# Altium schematic sheet Serial Monitor LCD Board Schematic.SchDoc("Serial Monitor LCD Board Schematic")
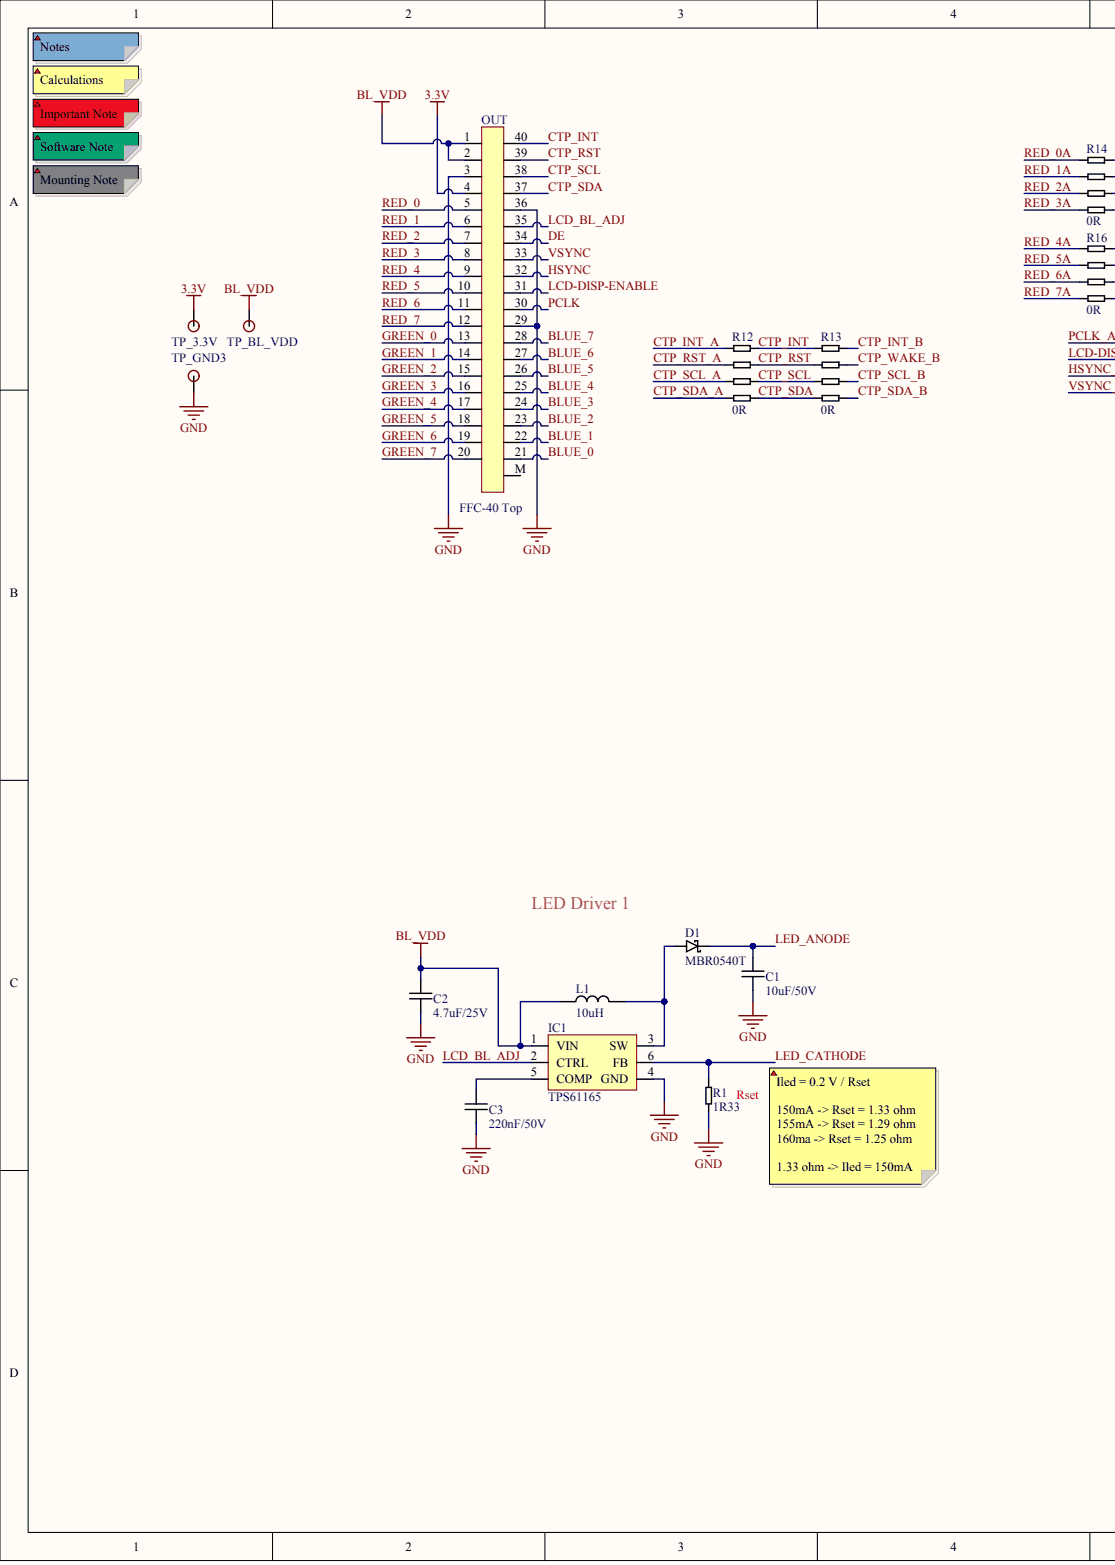
[diagram p0: Serial Monitor LCD Board Schematic.SchDoc, left]
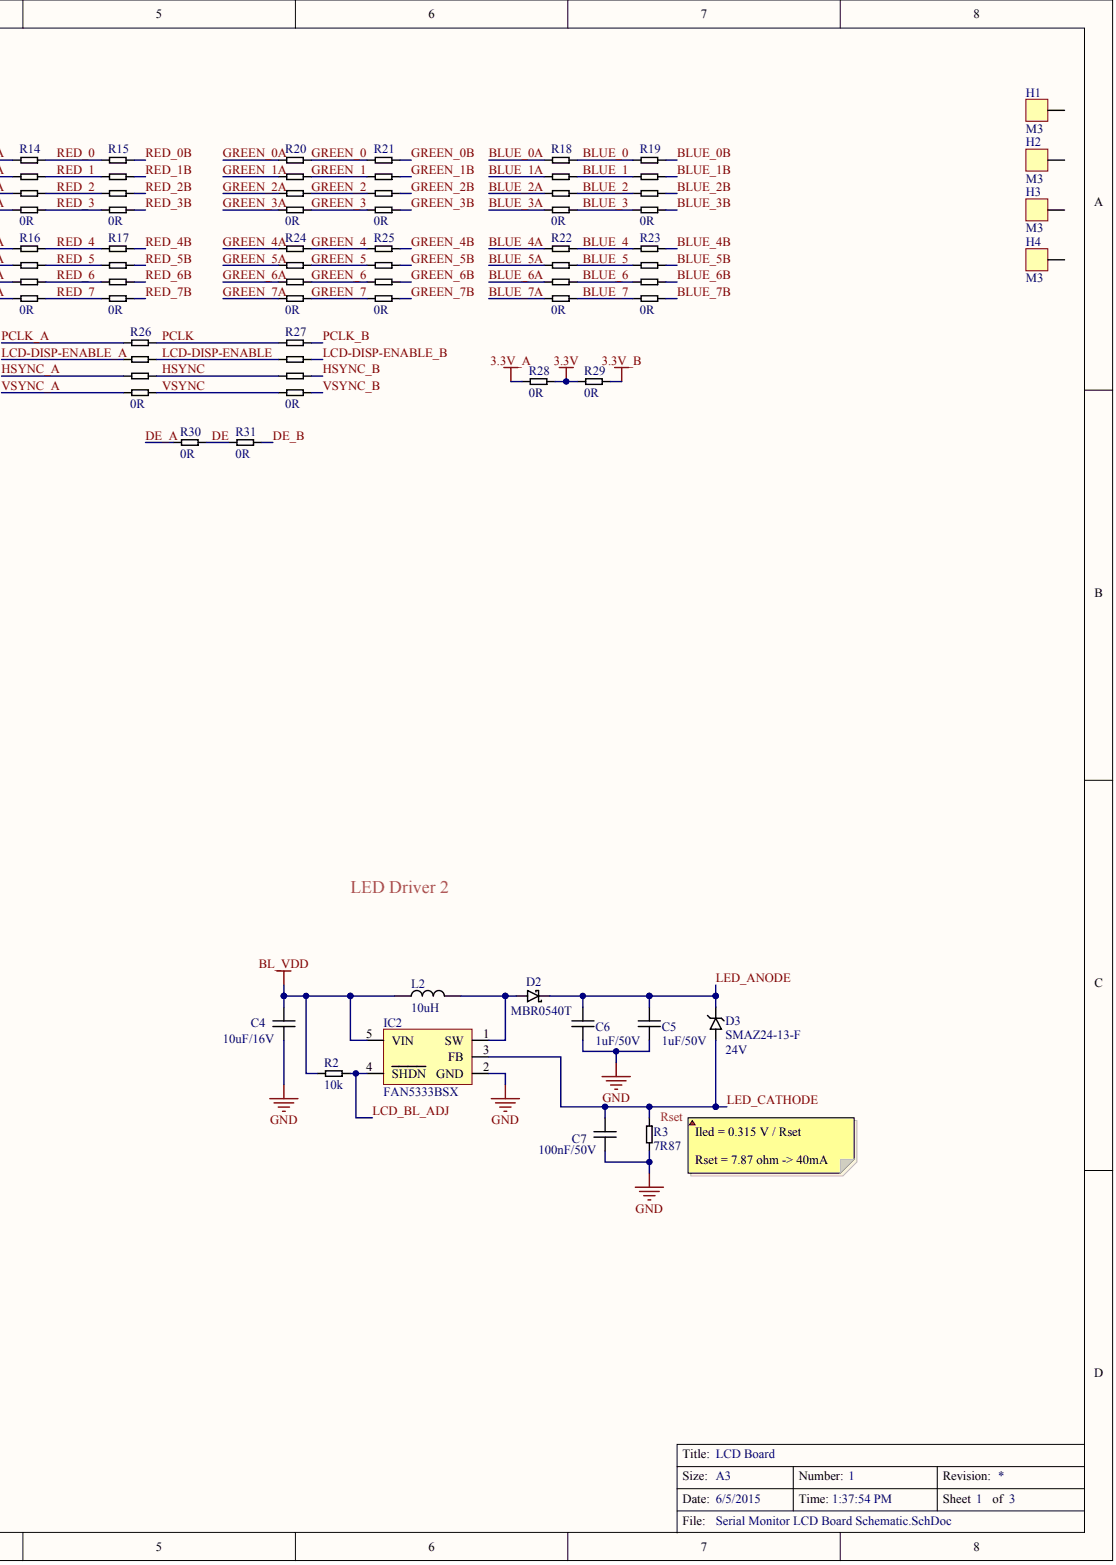
[diagram p1: Serial Monitor LCD Board Schematic.SchDoc, right]

## Parts
C1  value 10uF/50V  [1206]  (hidden comment: Cap_1206_10uF/50V)
C2  value 4.7uF/25V  [0805]  (hidden comment: Cap_0805_4.7uF/25V)
C3  value 220nF/50V  [0603]  (hidden comment: Cap_0603_220nF/50V)
C4  value 10uF/16V  [0805]  (hidden comment: Cap_0805_10uF/16V)
C5  value 1uF/50V  [0603]  (hidden comment: Cap_0603_1uF/50V)
C6  value 1uF/50V  [0603]  (hidden comment: Cap_0603_1uF/50V)
C7  value 100nF/50V  [0603]  (hidden comment: Cap_0603_100nF/50V)
D1  MBR0540  value MBR0540T  Part No.: MBR0540T
D2  MBR0540  value MBR0540T  Part No.: MBR0540T
D3  SMAZ24-13-F  Zener Voltage: 24V
H1  M3_SCREWHOLE  (value not drawn)
H2  value M3  (hidden comment: M3_SCREWHOLE)
H3  value M3  (hidden comment: M3_SCREWHOLE)
H4  value M3  (hidden comment: M3_SCREWHOLE)
IC1  TPS61165  [SOT-23-6]
IC2  FAN5333BSX  [SOT-23-5]
L1  value 10uH  [SMD SRN4028 4.8x4.8mm]  (hidden comment: Inductor_SRR4028_10uH)
L2  value 10uH  [SMD SRN4028 4.8x4.8mm]  (hidden comment: Inductor_SRR4028_10uH)
OUT  value FFC-40 Top  (hidden comment: FFC 40 pin 0.5mm Top)
R1  value 1R33  [0603]  (hidden comment: Res_0603_1R33)
R2  value 10k  [0603]  (hidden comment: Res_0603_10k)
R3  value 7R87  [0603]  (hidden comment: Res_0603_7R87)
R12  value 0R  [0804]  (hidden comment: Res_Array_0804_4x0R)
R13  value 0R  [0804]  (hidden comment: Res_Array_0804_4x0R)
R14  value 0R  [0804]  (hidden comment: Res_Array_0804_4x0R)
R15  value 0R  [0804]  (hidden comment: Res_Array_0804_4x0R)
R16  value 0R  [0804]  (hidden comment: Res_Array_0804_4x0R)
R17  value 0R  [0804]  (hidden comment: Res_Array_0804_4x0R)
R18  value 0R  [0804]  (hidden comment: Res_Array_0804_4x0R)
R19  value 0R  [0804]  (hidden comment: Res_Array_0804_4x0R)
R20  value 0R  [0804]  (hidden comment: Res_Array_0804_4x0R)
R21  value 0R  [0804]  (hidden comment: Res_Array_0804_4x0R)
R22  value 0R  [0804]  (hidden comment: Res_Array_0804_4x0R)
R23  value 0R  [0804]  (hidden comment: Res_Array_0804_4x0R)
R24  value 0R  [0804]  (hidden comment: Res_Array_0804_4x0R)
R25  value 0R  [0804]  (hidden comment: Res_Array_0804_4x0R)
R26  value 0R  [0804]  (hidden comment: Res_Array_0804_4x0R)
R27  value 0R  [0804]  (hidden comment: Res_Array_0804_4x0R)
R28  value 0R  [0603]  (hidden comment: Res_0603_0R)
R29  value 0R  [0603]  (hidden comment: Res_0603_0R)
R30  value 0R  [0603]  (hidden comment: Res_0603_0R)
R31  value 0R  [0603]  (hidden comment: Res_0603_0R)
TP_3.3V  Testpad  (value not drawn)
TP_BL_VDD  Testpad  (value not drawn)
TP_GND3  Testpad  (value not drawn)

## Nets
3.3V: OUT.4 R28.2 R29.1 TP_3.3V.1
3.3V_A: R28.1
3.3V_B: R29.2
BL_VDD: C2.2 C4.2 IC1.1(VIN) IC2.5(VIN) L1.1 L2.1 OUT.1 OUT.2 R2.1 TP_BL_VDD.1
GND: C1.1 C2.1 C3.1 C4.1 C5.1 C6.1 C7.1 IC1.4(GND) IC2.2(GND) OUT.3 OUT.29 OUT.36 R1.1 R3.1 TP_GND3.1
BLUE_0: OUT.21 R18.2 R19.1
BLUE_0A: R18.1
BLUE_0B: R19.2
BLUE_1: OUT.22 R18.4 R19.3
BLUE_1A: R18.3
BLUE_1B: R19.4
BLUE_2: OUT.23 R18.6 R19.5
BLUE_2A: R18.5
BLUE_2B: R19.6
BLUE_3: OUT.24 R18.8 R19.7
BLUE_3A: R18.7
BLUE_3B: R19.8
BLUE_4: OUT.25 R22.2 R23.1
BLUE_4A: R22.1
BLUE_4B: R23.2
BLUE_5: OUT.26 R22.4 R23.3
BLUE_5A: R22.3
BLUE_5B: R23.4
BLUE_6: OUT.27 R22.6 R23.5
BLUE_6A: R22.5
BLUE_6B: R23.6
BLUE_7: OUT.28 R22.8 R23.7
BLUE_7A: R22.7
BLUE_7B: R23.8
CTP_INT: OUT.40 R12.8 R13.7
CTP_INT_A: R12.7
CTP_INT_B: R13.8
CTP_RST: OUT.39 R12.6 R13.5
CTP_RST_A: R12.5
CTP_SCL: OUT.38 R12.4 R13.3
CTP_SCL_A: R12.3
CTP_SCL_B: R13.4
CTP_SDA: OUT.37 R12.2 R13.1
CTP_SDA_A: R12.1
CTP_SDA_B: R13.2
CTP_WAKE_B: R13.6
DE: OUT.34 R30.2 R31.1
DE_A: R30.1
DE_B: R31.2
GREEN_0: OUT.13 R20.2 R21.1
GREEN_0A: R20.1
GREEN_0B: R21.2
GREEN_1: OUT.14 R20.4 R21.3
GREEN_1A: R20.3
GREEN_1B: R21.4
GREEN_2: OUT.15 R20.6 R21.5
GREEN_2A: R20.5
GREEN_2B: R21.6
GREEN_3: OUT.16 R20.8 R21.7
GREEN_3A: R20.7
GREEN_3B: R21.8
GREEN_4: OUT.17 R24.2 R25.1
GREEN_4A: R24.1
GREEN_4B: R25.2
GREEN_5: OUT.18 R24.4 R25.3
GREEN_5A: R24.3
GREEN_5B: R25.4
GREEN_6: OUT.19 R24.6 R25.5
GREEN_6A: R24.5
GREEN_6B: R25.6
GREEN_7: OUT.20 R24.8 R25.7
GREEN_7A: R24.7
GREEN_7B: R25.8
HSYNC: OUT.32 R26.6 R27.5
HSYNC_A: R26.5
HSYNC_B: R27.6
LCD-DISP-ENABLE: OUT.31 R26.4 R27.3
LCD-DISP-ENABLE_A: R26.3
LCD-DISP-ENABLE_B: R27.4
LCD_BL_ADJ: IC1.2(CTRL) IC2.4(SHDN) OUT.35 R2.2
LED_ANODE: C1.2 C5.2 C6.2 D1.1 D2.1 D3.1
LED_CATHODE: C7.2 D3.2 IC1.6(FB) IC2.3(FB) R1.2 R3.2
PCLK: OUT.30 R26.2 R27.1
PCLK_A: R26.1
PCLK_B: R27.2
RED_0: OUT.5 R14.2 R15.1
RED_0A: R14.1
RED_0B: R15.2
RED_1: OUT.6 R14.4 R15.3
RED_1A: R14.3
RED_1B: R15.4
RED_2: OUT.7 R14.6 R15.5
RED_2A: R14.5
RED_2B: R15.6
RED_3: OUT.8 R14.8 R15.7
RED_3A: R14.7
RED_3B: R15.8
RED_4: OUT.9 R16.2 R17.1
RED_4A: R16.1
RED_4B: R17.2
RED_5: OUT.10 R16.4 R17.3
RED_5A: R16.3
RED_5B: R17.4
RED_6: OUT.11 R16.6 R17.5
RED_6A: R16.5
RED_6B: R17.6
RED_7: OUT.12 R16.8 R17.7
RED_7A: R16.7
RED_7B: R17.8
VSYNC: OUT.33 R26.8 R27.7
VSYNC_A: R26.7
VSYNC_B: R27.8
NetC3_2: C3.2 IC1.5(COMP)
NetD1_2: D1.2 IC1.3(SW) L1.2
NetD2_2: D2.2 IC2.1(SW) L2.2

Not connected: H1 HOLE; H2 HOLE; H3 HOLE; H4 HOLE; OUT M

## Library data of the parts
C1 = (no symbol name): Part No.: GRM31CR61H106KA12L; Manufacturer: Murata; RS: 790-0610; DigiKey: 490-5961-1-ND; Mouser: 81-GRM31CR61H106KA2L; Price SEK (No VAT): 3
C2 = (no symbol name): Part No.: GRM21BR61E475KA12L; Manufacturer: Murata; DigiKey: 490-3335-1-ND; Mouser: 81-GRM21BR61E475KA12; Price SEK (No VAT): 1
C3 = (no symbol name): Part No.: C1608X7R1H224K080AB; Manufacturer: TDK; RS: 788-2994 (50-pack); DigiKey: 445-7408-1-ND; Mouser: 810-C1608X7R1H224K; Price SEK (No VAT): 0,5
C4 = (no symbol name): Part No.: GRM21BR61C106KE15L; Manufacturer: Murata; DigiKey: 490-3886-1-ND; Price SEK (No VAT): 1,5; Mouser: 81-GRM21BR61C106KE15
C5 C6 = (no symbol name): Part No.: C1608X5R1H105K080AB; Manufacturer: TDK; Mouser: 810-C1608X5R1H105K; Price SEK (No VAT): 1; DigiKey: 445-7468-1-ND
C7 = (no symbol name): Part No.: C1608X7R1H104K080AA; Manufacturer: TDK; RS: 741-4459 (500-pack); DigiKey: 445-1314-1-ND; Mouser: 810-C1608X7R1H104K; Price SEK (No VAT): 0,2
D1 D2 = (no symbol name): Manufacturer: ON Semiconductor; Mouser: 863-MBR0540T1G; Price SEK (No VAT): 3; DigiKey: MBR0540T1GOSCT-ND
D3 = (no symbol name): Manufacturer: Diodes Inc; RS: 751-5039 (50-pack); Part No.: SMAZ24-13-F; Mouser: 621-SMAZ24-F; Price SEK (No VAT): 4; DigiKey: SMAZ24-FDICT-ND; Farnell: *; Value: SMAZ24-13-F
H1 = (no symbol name): Value: M3
IC1 = (no symbol name): Part No.: TPS61165DBV; Manufacturer: TI; RS: 812-3634 (10-pack); DigiKey: 296-27597-1-ND; Price SEK (No VAT): 17; Mouser: 595-TPS61165DBVR; Value: TPS61165
IC2 = (no symbol name): Manufacturer: Fairchild; RS: 739-5995 (5-pack); DigiKey: FAN5333BSXCT-ND; Part No.: FAN5333BSX; Price SEK (No VAT): 10; Mouser: 512-FAN5333BSX; Farnell: 1659466; Value: FAN5333BSX
L1 L2 = (no symbol name): Part No.: SRR4028-100Y; Manufacturer: Bourns; RS: 727-2029 (5-pack); Mouser: 652-SRR4028-100Y; Price SEK (No VAT): 6; DigiKey: SRR4028-100YCT-ND; Farnell: *
OUT = (no symbol name): Part No.: 54104-4031; Manufacturer: Molex; RS: 670-2645 (10-pack); Mouser: 538-54132-5062; DigiKey: WM6785CT-ND; Farnell: *; Price SEK (No VAT): 31
R1 = (no symbol name): Part No.: CRCW06031R33FKEA; Manufacturer: Vishay; DigiKey: 541-1.33HHCT-ND; Mouser: 71-CRCW06031R33FKEA; Price SEK (No VAT): 0,1
R2 = (no symbol name): Part No.: CRCW060310K0FKEA; Manufacturer: Vishay; Price SEK (No VAT): 0,1; Mouser: 71-CRCW0603-10K-E3; DigiKey: 541-10.0KHCT-ND
R3 = (no symbol name): Part No.: CRCW06037R87FKEA; Manufacturer: Vishay; Price SEK (No VAT): 0,1; DigiKey: 541-7.87HHCT-ND; Mouser: 71-CRCW06037R87FKEA
R12-R27 = (no symbol name): Part No.: CRA04S0830000ZTD; Manufacturer: Vishay; Price SEK (No VAT): 1; DigiKey: CRA4S80.0CT-ND; Mouser: 71-CRA04S0803000JRT7
R28-R31 = (no symbol name): Part No.: CRCW06030000Z0EA; Manufacturer: Vishay; Price SEK (No VAT): 0,1; DigiKey: 541-0.0GCT-ND; Mouser: 71-CRCW0603-0-E3
